# Altium schematic sheet IowaStateLEDSch.SchDoc(IowaStateLEDSch)
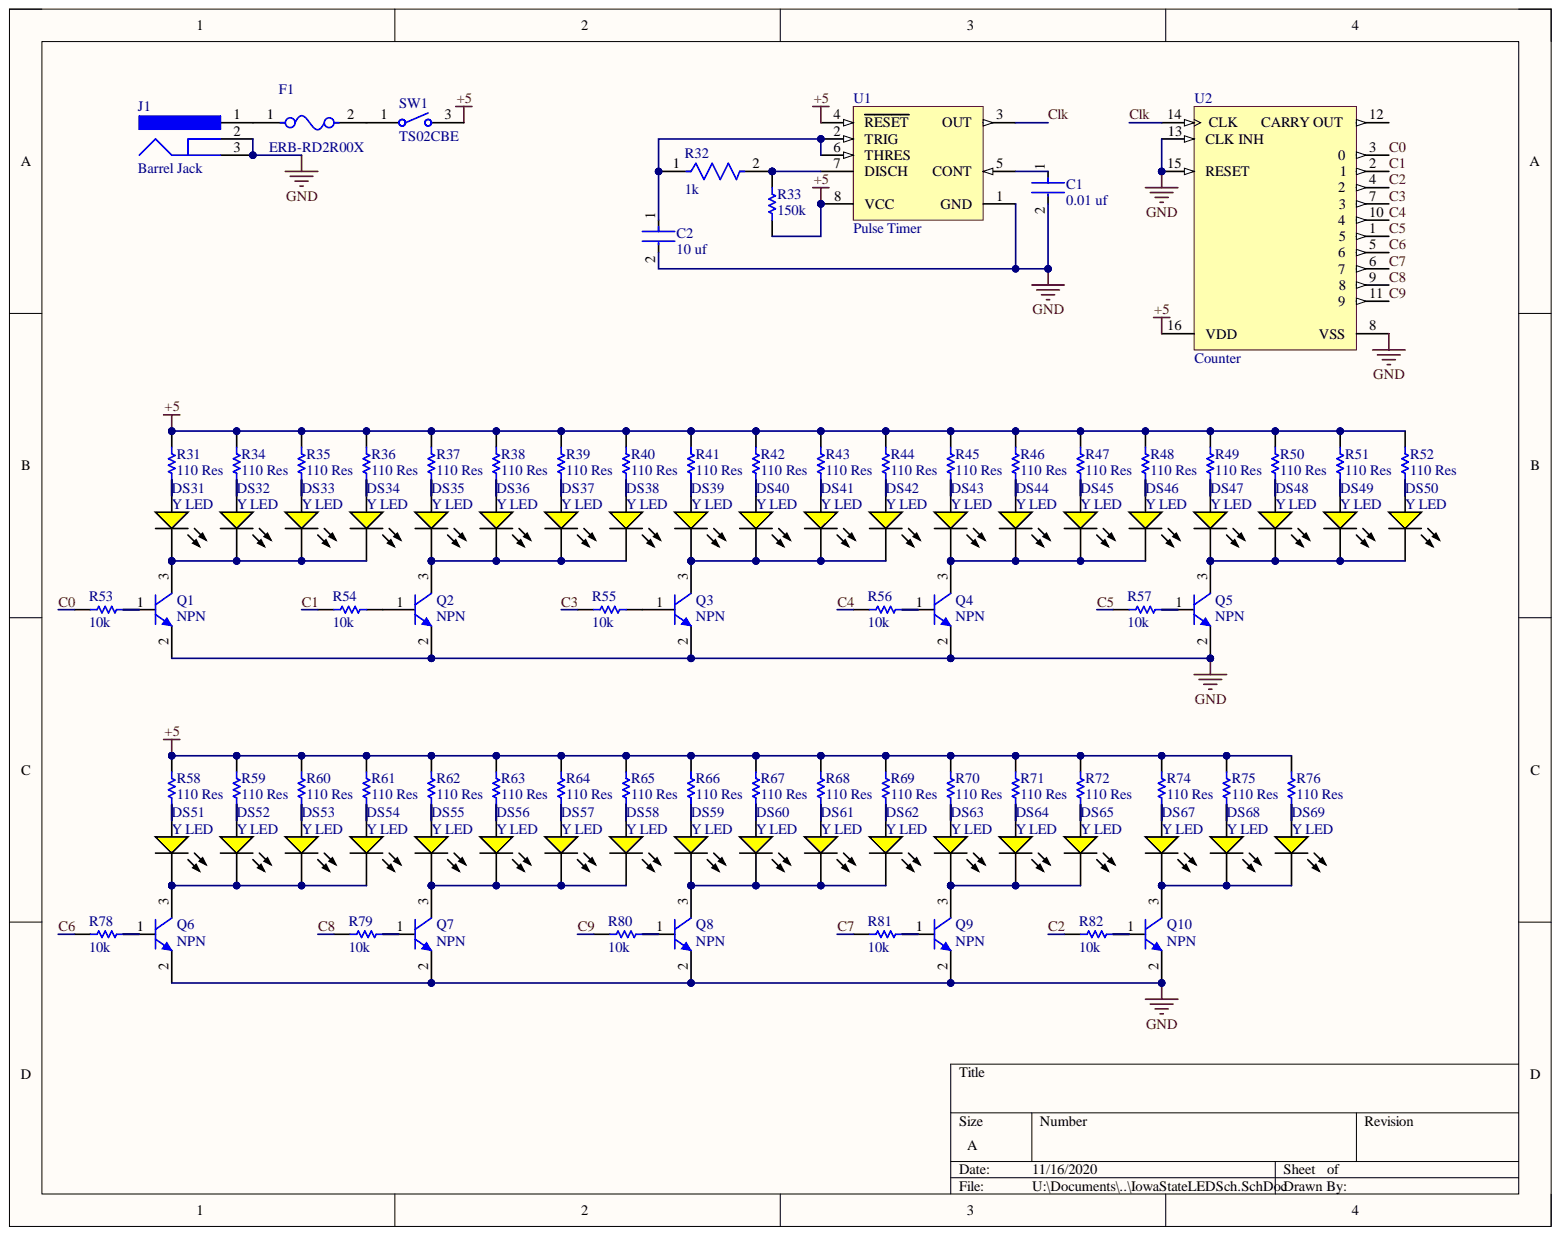
[diagram p0: IowaStateLEDSch.SchDoc]

## Parts
C1  0.01 uf  [FP-C1206C-EB-MFG]
C2  10 uf  [FP-CL21-IPC_C]
DS31  Y LED  [LTST-C150KSKT_V]
DS32  Y LED  [LTST-C150KSKT_V]
DS33  Y LED  [LTST-C150KSKT_V]
DS34  Y LED  [LTST-C150KSKT_V]
DS35  Y LED  [LTST-C150KSKT_V]
DS36  Y LED  [LTST-C150KSKT_V]
DS37  Y LED  [LTST-C150KSKT_V]
DS38  Y LED  [LTST-C150KSKT_V]
DS39  Y LED  [LTST-C150KSKT_V]
DS40  Y LED  [LTST-C150KSKT_V]
DS41  Y LED  [LTST-C150KSKT_V]
DS42  Y LED  [LTST-C150KSKT_V]
DS43  Y LED  [LTST-C150KSKT_V]
DS44  Y LED  [LTST-C150KSKT_V]
DS45  Y LED  [LTST-C150KSKT_V]
DS46  Y LED  [LTST-C150KSKT_V]
DS47  Y LED  [LTST-C150KSKT_V]
DS48  Y LED  [LTST-C150KSKT_V]
DS49  Y LED  [LTST-C150KSKT_V]
DS50  Y LED  [LTST-C150KSKT_V]
DS51  Y LED  [LTST-C150KSKT_V]
DS52  Y LED  [LTST-C150KSKT_V]
DS53  Y LED  [LTST-C150KSKT_V]
DS54  Y LED  [LTST-C150KSKT_V]
DS55  Y LED  [LTST-C150KSKT_V]
DS56  Y LED  [LTST-C150KSKT_V]
DS57  Y LED  [LTST-C150KSKT_V]
DS58  Y LED  [LTST-C150KSKT_V]
DS59  Y LED  [LTST-C150KSKT_V]
DS60  Y LED  [LTST-C150KSKT_V]
DS61  Y LED  [LTST-C150KSKT_V]
DS62  Y LED  [LTST-C150KSKT_V]
DS63  Y LED  [LTST-C150KSKT_V]
DS64  Y LED  [LTST-C150KSKT_V]
DS65  Y LED  [LTST-C150KSKT_V]
DS67  Y LED  [LTST-C150KSKT_V]
DS68  Y LED  [LTST-C150KSKT_V]
DS69  Y LED  [LTST-C150KSKT_V]
F1  ERB-RD2R00X  [FP-ERBRD-MFG]
J1  Barrel Jack  [KYCN-KLDX-0202-A_V]
Q1  NPN  [DIOD-SOT23-3_V]
Q2  NPN  [DIOD-SOT23-3_V]
Q3  NPN  [DIOD-SOT23-3_V]
Q4  NPN  [DIOD-SOT23-3_V]
Q5  NPN  [DIOD-SOT23-3_V]
Q6  NPN  [DIOD-SOT23-3_V]
Q7  NPN  [DIOD-SOT23-3_V]
Q8  NPN  [DIOD-SOT23-3_V]
Q9  NPN  [DIOD-SOT23-3_V]
Q10  NPN  [DIOD-SOT23-3_V]
R31  110 Res  [RESC3216X70X50LL05T20]
R32  1k  [FP-CR1206-IPC_C]
R33  150k  [RESC3216X70X40LL20T20]
R34  110 Res  [RESC3216X70X50LL05T20]
R35  110 Res  [RESC3216X70X50LL05T20]
R36  110 Res  [RESC3216X70X50LL05T20]
R37  110 Res  [RESC3216X70X50LL05T20]
R38  110 Res  [RESC3216X70X50LL05T20]
R39  110 Res  [RESC3216X70X50LL05T20]
R40  110 Res  [RESC3216X70X50LL05T20]
R41  110 Res  [RESC3216X70X50LL05T20]
R42  110 Res  [RESC3216X70X50LL05T20]
R43  110 Res  [RESC3216X70X50LL05T20]
R44  110 Res  [RESC3216X70X50LL05T20]
R45  110 Res  [RESC3216X70X50LL05T20]
R46  110 Res  [RESC3216X70X50LL05T20]
R47  110 Res  [RESC3216X70X50LL05T20]
R48  110 Res  [RESC3216X70X50LL05T20]
R49  110 Res  [RESC3216X70X50LL05T20]
R50  110 Res  [RESC3216X70X50LL05T20]
R51  110 Res  [RESC3216X70X50LL05T20]
R52  110 Res  [RESC3216X70X50LL05T20]
R53  10k  [RESC3116X65X50NL20T20]
R54  10k  [RESC3116X65X50NL20T20]
R55  10k  [RESC3116X65X50NL20T20]
R56  10k  [RESC3116X65X50NL20T20]
R57  10k  [RESC3116X65X50NL20T20]
R58  110 Res  [RESC3216X70X50LL05T20]
R59  110 Res  [RESC3216X70X50LL05T20]
R60  110 Res  [RESC3216X70X50LL05T20]
R61  110 Res  [RESC3216X70X50LL05T20]
R62  110 Res  [RESC3216X70X50LL05T20]
R63  110 Res  [RESC3216X70X50LL05T20]
R64  110 Res  [RESC3216X70X50LL05T20]
R65  110 Res  [RESC3216X70X50LL05T20]
R66  110 Res  [RESC3216X70X50LL05T20]
R67  110 Res  [RESC3216X70X50LL05T20]
R68  110 Res  [RESC3216X70X50LL05T20]
R69  110 Res  [RESC3216X70X50LL05T20]
R70  110 Res  [RESC3216X70X50LL05T20]
R71  110 Res  [RESC3216X70X50LL05T20]
R72  110 Res  [RESC3216X70X50LL05T20]
R74  110 Res  [RESC3216X70X50LL05T20]
R75  110 Res  [RESC3216X70X50LL05T20]
R76  110 Res  [RESC3216X70X50LL05T20]
R78  10k  [RESC3116X65X50NL20T20]
R79  10k  [RESC3116X65X50NL20T20]
R80  10k  [RESC3116X65X50NL20T20]
R81  10k  [RESC3116X65X50NL20T20]
R82  10k  [RESC3116X65X50NL20T20]
SW1  TS02CBE  [CKCP-TS02CBE_V]
U1  Pulse Timer  [D0008A_M]
U2  Counter  [D0016A_L]

## Nets
+5: R31.1 R33.1 R34.1 R35.1 R36.1 R37.1 R38.1 R39.1 R40.1 R41.1 R42.1 R43.1 R44.1 R45.1 R46.1 R47.1 R48.1 R49.1 R50.1 R51.1 R52.1 R58.1 R59.1 R60.1 R61.1 R62.1 R63.1 R64.1 R65.1 R66.1 R67.1 R68.1 R69.1 R70.1 R71.1 R72.1 R74.1 R75.1 R76.1 SW1.3 U1.4(RESET) U1.8(VCC) U2.16(VDD)
GND: C1.2 C2.2 J1.2 J1.3 Q1.2 Q2.2 Q3.2 Q4.2 Q5.2 Q6.2 Q7.2 Q8.2 Q9.2 Q10.2 U1.1(GND) U2.8(VSS) U2.13(CLK_INH) U2.15(RESET)
C0: R53.1 U2.3
C1: R54.1 U2.2
C2: R82.1 U2.4
C3: R55.1 U2.7
C4: R56.1 U2.10
C5: R57.1 U2.1
C6: R78.1 U2.5
C7: R81.1 U2.6
C8: R79.1 U2.9
C9: R80.1 U2.11
Clk: U1.3(OUT) U2.14(CLK)
NetC1_1: C1.1 U1.5(CONT)
NetC2_1: C2.1 R32.1 U1.2(TRIG) U1.6(THRES)
NetDS31_1: DS31.1 R31.2
NetDS31_2: DS31.2 DS32.2 DS33.2 DS34.2 Q1.3
NetDS32_1: DS32.1 R34.2
NetDS33_1: DS33.1 R35.2
NetDS34_1: DS34.1 R36.2
NetDS35_1: DS35.1 R37.2
NetDS35_2: DS35.2 DS36.2 DS37.2 DS38.2 Q2.3
NetDS36_1: DS36.1 R38.2
NetDS37_1: DS37.1 R39.2
NetDS38_1: DS38.1 R40.2
NetDS39_1: DS39.1 R41.2
NetDS39_2: DS39.2 DS40.2 DS41.2 DS42.2 Q3.3
NetDS40_1: DS40.1 R42.2
NetDS41_1: DS41.1 R43.2
NetDS42_1: DS42.1 R44.2
NetDS43_1: DS43.1 R45.2
NetDS43_2: DS43.2 DS44.2 DS45.2 DS46.2 Q4.3
NetDS44_1: DS44.1 R46.2
NetDS45_1: DS45.1 R47.2
NetDS46_1: DS46.1 R48.2
NetDS47_1: DS47.1 R49.2
NetDS47_2: DS47.2 DS48.2 DS49.2 DS50.2 Q5.3
NetDS48_1: DS48.1 R50.2
NetDS49_1: DS49.1 R51.2
NetDS50_1: DS50.1 R52.2
NetDS51_1: DS51.1 R58.2
NetDS51_2: DS51.2 DS52.2 DS53.2 DS54.2 Q6.3
NetDS52_1: DS52.1 R59.2
NetDS53_1: DS53.1 R60.2
NetDS54_1: DS54.1 R61.2
NetDS55_1: DS55.1 R62.2
NetDS55_2: DS55.2 DS56.2 DS57.2 DS58.2 Q7.3
NetDS56_1: DS56.1 R63.2
NetDS57_1: DS57.1 R64.2
NetDS58_1: DS58.1 R65.2
NetDS59_1: DS59.1 R66.2
NetDS59_2: DS59.2 DS60.2 DS61.2 DS62.2 Q8.3
NetDS60_1: DS60.1 R67.2
NetDS61_1: DS61.1 R68.2
NetDS62_1: DS62.1 R69.2
NetDS63_1: DS63.1 R70.2
NetDS63_2: DS63.2 DS64.2 DS65.2 Q9.3
NetDS64_1: DS64.1 R71.2
NetDS65_1: DS65.1 R72.2
NetDS67_1: DS67.1 R74.2
NetDS67_2: DS67.2 DS68.2 DS69.2 Q10.3
NetDS68_1: DS68.1 R75.2
NetDS69_1: DS69.1 R76.2
NetF1_1: F1.1 J1.1
NetF1_2: F1.2 SW1.1
NetQ1_1: Q1.1 R53.2
NetQ2_1: Q2.1 R54.2
NetQ3_1: Q3.1 R55.2
NetQ4_1: Q4.1 R56.2
NetQ5_1: Q5.1 R57.2
NetQ6_1: Q6.1 R78.2
NetQ7_1: Q7.1 R79.2
NetQ8_1: Q8.1 R80.2
NetQ9_1: Q9.1 R81.2
NetQ10_1: Q10.1 R82.2
NetR32_2: R32.2 R33.2 U1.7(DISCH)

Not connected: U2 12(CARRY_OUT)

## Library data of the parts
C1 = CMP-03020-025386-1: C1206 10,000 pF X7R 10.00% 50 V; Manufacturer: KEMET; Manufacturer Part Number: C1206C103K5RAC; Automotive Grade: Grade 0; Material: Ceramic; Temperature Coefficient: 15%; Tolerance: 0.1; Voltage Rating: 50 V; Automotive: Yes; Capacitance: 10,000 pF; Category: Cap; Component Kind: Standard; ComponentLink2Description: Datasheet; ComponentLink2URL: https://content.kemet.com/datasheets/KEM_C1007_X8R_ULTRA_150C_SMD.pdf; Device Class L1: Passive Components; Device Class L2: Capacitors; Device Class L3: Ceramic Capacitors; Height: 0.88mm; Ipc Land Pattern Name: CAPC320160X78; Lead Free: Yes; Library Name: Altium Content Vault; Mouser Description: Cap Ceramic 0.01uF 50V X7R 10% Pad SMD 1206 125C Bulk; Octopart: https://octopart.com/c1206c103k5rac-kemet-1311444; Package: C1206C-EB; RoHS: TRUE; Temperature: -55°C to +150°C; Temperature Characteristic: X7R; Temperature Range High: +150°C; Temperature Range Low: -55°C; Value: 10,000 pF
C2 = CMP-13271-001427-1: CL21 Series 0805 10uF 6.3V ±10% Tolerance X7R Multilayer Ceramic Chip Capacitor; Capacitance: 10uF; Case Code (Imperial): 0805; Case Code (Metric): 2012; Case/Package: 0805; Component Kind: Standard; ComponentLink1Description: Digikey order; ComponentLink1URL: https://www.digikey.com/en/products/detail/CL21B106KQQNNNG/1276-6474-1-ND/5958102?utm_campaign=buynow&utm_medium=aggregator&curr=usd&utm_source=octopart; Depth: 1.25mm; Dielectric: X7R; Height: 1.25mm; Lead Free: Lead Free; Length: 2mm; Library Name: Altium Content Vault; Max Operating Temperature: 125°C; Min Operating Temperature: -55°C; Mount: Surface Mount; Package Quantity: 3000; Packaging: Tape and Reel; Thickness: 0.055inch; Tolerance: 10%; Voltage: 6.3V; Voltage Rating: 6.3V; Width: 0.049inch
DS31-DS65 DS67-DS69 = CMP-2000-06618-1: LED Yellow, 75 mW, 80 mA, -55 to 85 degC, 2-Pin SMD, RoHS, Tape and Reel; Manufacturer: Lite-On; Chip Material: AlGaInP; DELETED: Compliant; Dominant Wave Length: 587nm; Emitting Color: Amber; Forward Current: 30mA; Forward Voltage: 2.4V; High Brightness: Yes; Lum Intensity: 60mcd; Main Category: ChipLED; Number of  Elements: 1; Peak Wavelength: 588nm; Power Dissipation: 75W; Product Depth (mm): 1.6mm; Product Height (mm): 1.1mm; Product Length (mm): 3.2mm; Rad Hardened: No; Reverse Voltage: 5V; Shape Type: Rectangular; Test Current (It): 20mA; Type: Uni-Color; Viewing Angle: 130deg; Component Kind: Standard; Datasheet URL: http://optoelectronics.liteon.com/upload/download/DS-22-99-0187/S_110_LTST-C150KSKT.pdf; Datasheet Version: Rev. E, 02/2005; Lens Dimensions: 2.06X1.6X0.6mm; Library Name: Altium Content Vault; Luminous Intensity: 60mcd; Manufacturer URL: http://www.us.liteon.com/; Mounting: SurfaceMount; Mounting Technology: SurfaceMount; Operating Temp Range: -55Cto85C; Operating Temperature Classification: Industrial; Package Description: 2-Pin Surface Mount Device, Body 3.2 x 1.6 mm; Package Reference: C150KSKT; Package Type: ChipLed; Packaging: TapeandReel; Pin Count: 2
F1 = CMP-05590-000001-1: 2A  AC 32V DC Fuse Board Mount (Cartridge Style Excluded) Surface Mount 0402 (1005 Metric); Manufacturer: Panasonic Electronic Components; Fuse Blow Speed: Fast; Fuse Interrupt Dc Current: 35A; Resistance: 53mΩ; Value: 53mΩ; Automotive: No; Category: Fuse; Component Kind: Standard; ComponentLink2Description: Datasheet; ComponentLink2URL: https://industrial.panasonic.com/cdbs/www-data/pdf/AFA0000/AFA0000C7.pdf; Device Class L1: Circuit Protection; Device Class L2: Fuses; Device Class L3: unset; Digikey Description: FUSE BOARD MOUNT 2A 32VDC 0402; Fuse Current: 2A; Height: 0.49mm; Lead Free: Yes; Library Name: Altium Content Vault; Manufacturer Part Number: ERB-RD2R00X; Max Fuse Dc Voltage: 32V; Mouser Description: Surface Mount Fuses 2A 0402 micro chip; Octopart: https://octopart.com/erb-rd2r00x-panasonic-21220532; Package: ERBRD; RoHS: TRUE; Temperature: -40°C to +125°C; Temperature Range High: +125°C; Temperature Range Low: -40°C; Voltage Rating: 32V
J1 = CMP-1673-00001-5: DC Power Jack, 24 V, 3.5 A, -25 to 85 degC, 3-Pin THD, RoHS; Manufacturer: Kycon; Current Rating: 3.5 A; Voltage Rating: 24 V; Colour: Black; Component Kind: Standard; ComponentLink1Description: Manufacturer URL; ComponentLink1URL: http://www.kycon.com/; ComponentLink2Description: Datasheet; ComponentLink2URL: http://www.kycon.com/Pub_Eng_Draw/KLDX-0202-X.PDF; ComponentLink3Description: Wall Adapter; ComponentLink3URL: https://www.digikey.com/en/products/detail/xp-power/VEL05US050-US-JA/5023713; Connector Type: Power; Contact Gender: Female; Contact Material: Brass; Contact Plating: Tin; DatasheetVersion: Rev. D3, 06/2013; Height: 10.8 mm; Housing Material: PBT; Length: 9.2 mm; Library Name: Altium Content Vault; Max Operating Temperature: 85 degC; Min Operating Temperature: -25 degC; Mount: Through Hole; Mounting Technology: Through Hole; Package Quantity: 500; PackageDescription: 3-Pin Through Hole Device, Body 14.5 x 9 mm; PackageReference: KLDX-0202-A; Pins: 2; RoHSCompliant: true
Q1-Q10 = CMP-1693-00003-3: NPN Small Signal Transistor, 40 V, -55 to 150 degC, 3-Pin SOT23, RoHS, Tape and Reel; PartNumber: MMBT2222A-7-F; Case/Package: SOT-23; Component Kind: Standard; ComponentLink1Description: Manufacturer URL; ComponentLink1URL: http://www.diodes.com/; ComponentLink2Description: Datasheet; ComponentLink2URL: http://www.diodes.com/_files/datasheets/ds30041.pdf; DatasheetVersion: Rev. 16 - 2; Height: 1.1 mm; Length: 3 mm; Library Name: Altium Content Vault; Max Operating Temperature: 150 degC; Min Operating Temperature: -55 degC; Mount: Surface Mount; Mounting Technology: Surface Mount; Package Quantity: 3000; PackageDescription: 3-Pin Small Outline Transistor, Body 2.9 x 1.3 mm, Pitch 0.95 mm; Packaging: Tape and Reel; Pins: 3; Radiation Hardening: No; REACH SVHC: No SVHC; RoHSCompliant: True; Weight: 0.000282 oz; Width: 1.4 mm
R31 R34-R52 R58-R72 R74-R76 = CMP-2003-00040-1: Manufacturer: Panasonic; Part Number: ERJ-8ENF1100V; Component Kind: Standard; Library Name: Altium Content Vault
R32 = CMP-07231-001820-1: Thick Film Chip Resistors 1206 1kΩ 0.25W 5% 200ppm/°C; Power Rating: 250mW; Resistance: 1kR; Voltage Rating: 200V; Case Code (Imperial): 1206; Case Code (Metric): 3216; Case/Package: 1206; Component Kind: Standard; Composition: Thick Film; Contact Plating: Tin; Depth: 1.6mm; Height: 0.6mm; Length: 3.2mm; Library Name: Altium Content Vault; Max Operating Temperature: 155°C; Military Standard: Not; Min Operating Temperature: -55°C; Mount: Surface Mount; Number of Pins: 2; Package Quantity: 5000; Packaging: Tape and Reel; Radiation Hardening: No; REACH SVHC: No SVHC; Temperature Coefficient: 200ppm/°C; Termination: SMD/SMT; Thickness: 610um; Tolerance: 5%; Voltage Rating (DC): 200V; Width: 1.6mm
R33 = CMP-2003-04546-1: Manufacturer: KOA Speer; Part Number: RK73B2BTTD154J; Component Kind: Standard; Library Name: Altium Content Vault
R53-R57 R78-R82 = CMP-2003-04883-1: Manufacturer: Vishay Dale; Part Number: CRCW120610K0FKEAHP; Component Kind: Standard; Library Name: Altium Content Vault
SW1 = CMP-2000-07317-1: Tiny Slide Switch, SPST, 20 V, -30 to 85 degC, 2-Pin THD, RoHS, Bulk; Manufacturer: C&K Components; AC Voltage Rating (Max): 20V; Actuator Material: Nylon; Actuator Style: TopSlide; Product Depth (mm): 5.08mm; Product Height (mm): 12.91mm; Product Length (mm): 10.16mm; Switch Configuration: OFFNoneON; Component Kind: Standard; Contact Material: CopperAlloy; Contact Plating: Gold/Nickel; Datasheet URL: http://www.ck-components.com/media/1426/ts_12feb16.pdf; Datasheet Version: 02/2016; DC Voltage Rating (Max): 20V; Housing Material: N/A/StainlessSteel; Library Name: Altium Content Vault; Manufacturer URL: http://www.ckswitches.com/; Mounting Style: ThroughHole; Mounting Technology: ThroughHole; Operating Temp Range: -30Cto85C; Package Description: 2-Pin Through Hole Device, Body 10.16 x 5.08 mm; Package Reference: TS02CBE; Pole Throw Configuration: SPST; Terminal Type: PCPins; Type: Tiny
U1 = CMP-1685-00007-1: Precision Timer, 4.5 to 16 V, 0 to 70 degC, 8-Pin SOIC (D8), Green (RoHS & no Sb/Br), Tape and Reel; Case\Package: SMA; Forward Current: 1 A; Forward Voltage: 450 mV; Max Surge Current: 30 A; Peak Reverse Current: 500 uA; Code_JEDEC: MS-012; Component Kind: Standard; ComponentLink1Description: Manufacturer URL; ComponentLink1URL: http://www.ti.com/; ComponentLink2Description: Datasheet; ComponentLink2URL: http://www.ti.com/general/docs/lit/getliterature.tsp?genericPartNumber=NE555&fileType=pdf; ComponentLink3Description: Package Specification; ComponentLink3URL: http://www.ti.com/lit/ml/msoi002j/msoi002j.pdf; DatasheetVersion: SLFS022I, 09/2014; Height: 2.44 mm; Length: 4.57 mm; Library Name: Altium Content Vault; Max Operating Temperature: 125 degC; Min Operating Temperature: -55 degC; Mount: Surface Mount; Package Quantity: 1; PackageDescription: 8-Pin Small Outline Integrated Circuit, Body 4.9 x 3.9 mm, Pitch 1.27 mm; PackageReference: D0008A; PackageVersion: 4040047-3/M, 06/2011; Packaging: Cut Tape; Pins: 2; Radiation Hardening: No; REACH SVHC: Unknown; RoHSCompliant: true; Width: 2.84 mm
U2 = CMP-1623-00072-2: CMOS Decade Counter with 10 Decoded Outputs, D0016A, LARGE T&R; Generic Part Number: CD4017B; Manufacturer: Texas Instruments; Bits(#): 10; F @ Nom Voltage(Max)(Mhz): 8; ICC @ Nom Voltage(Max)(mA): 0.03; Inputs: 1; Output Drive (IOL/IOH)(Max)(mA): 1.5/-1.5; Rating: Military; Schmitt Trigger: No; Technology Family: CD4000; tpd @ Nom Voltage(Max)(ns): 270; VCC(Max)(V): 18; VCC(Min)(V): 3; Code_JEDEC: MS-012 variation AC; Component Kind: Standard; DatasheetVersion: SCHS027C; Function: Counter; Input Type: CMOS; Library Name: Altium Content Vault; Operating Temperature Range(C): -55 to 125; Output Type: CMOS; PackageReference: D0016A; Type: Decade; Voltage(Nom)(V): 10
